# Altium schematic sheet 08_SOM.SchDoc(08_SOM)
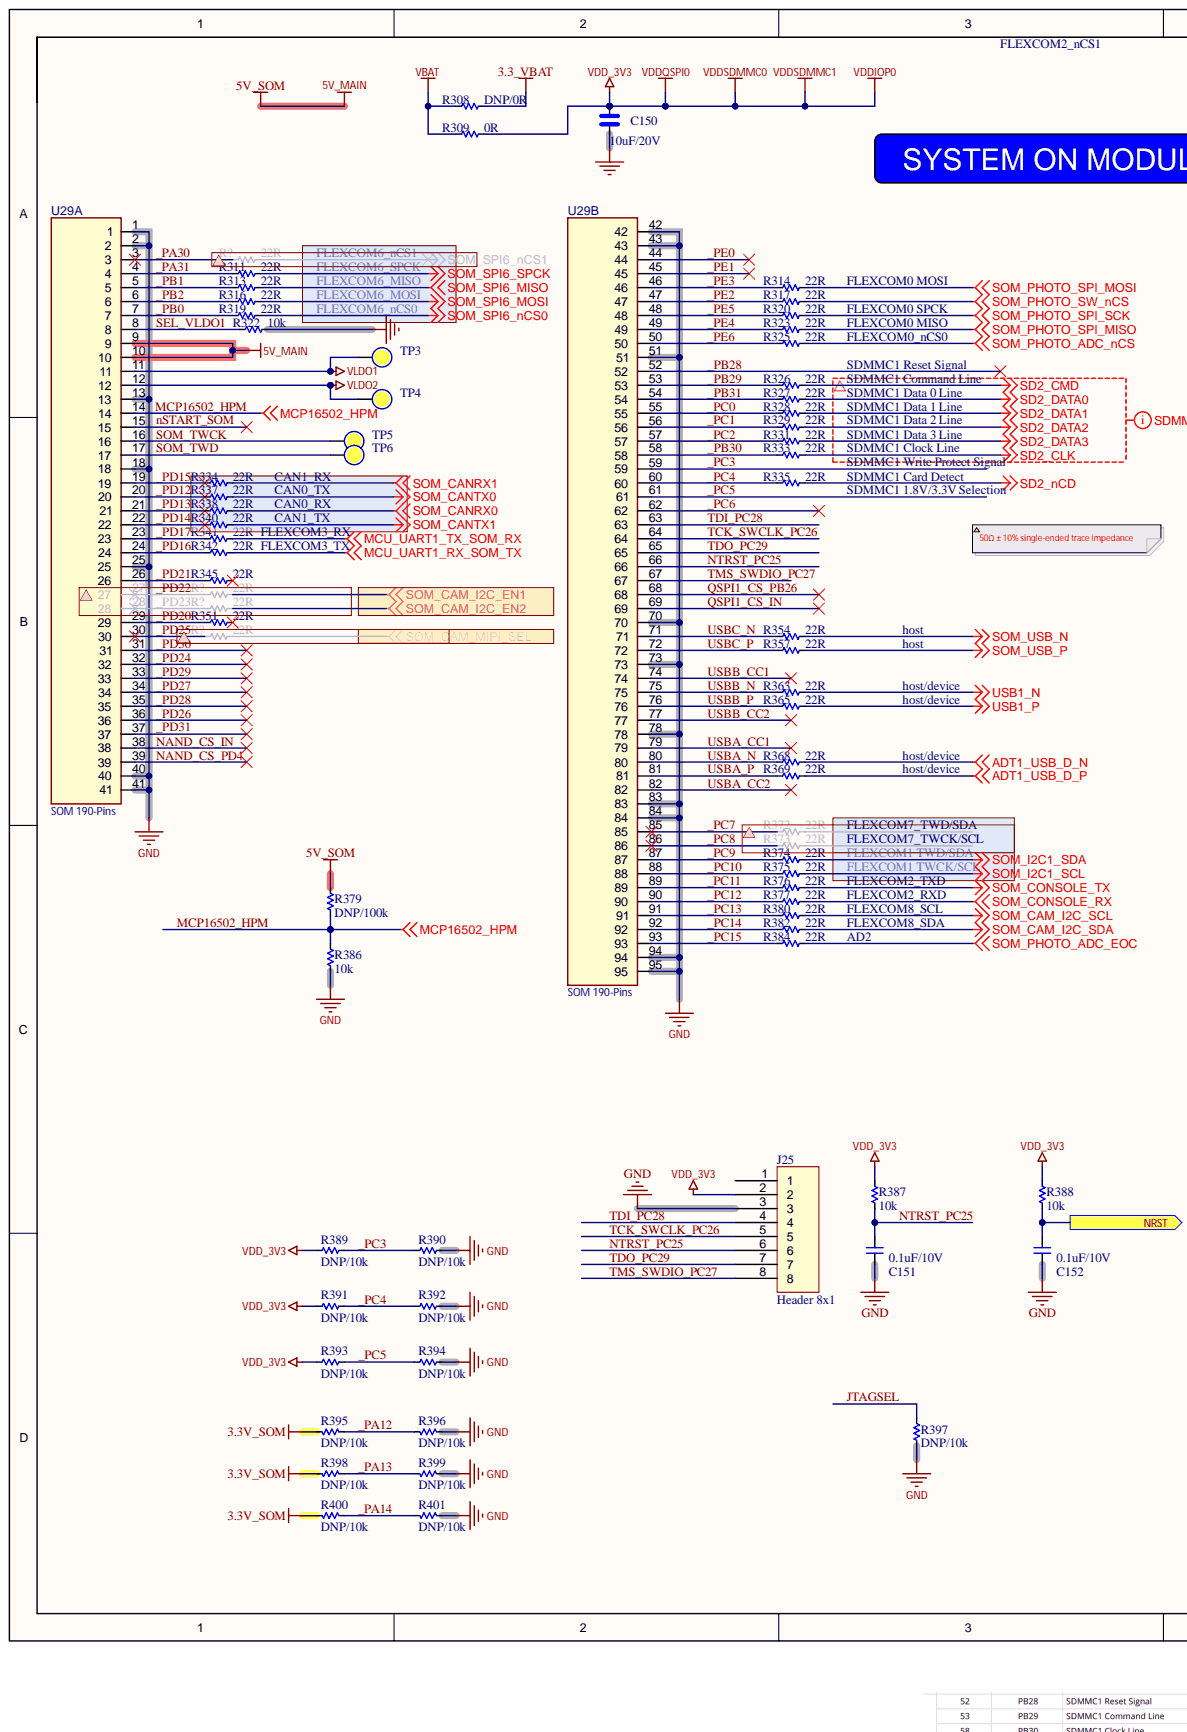
[diagram p0: 08_SOM.SchDoc, left]
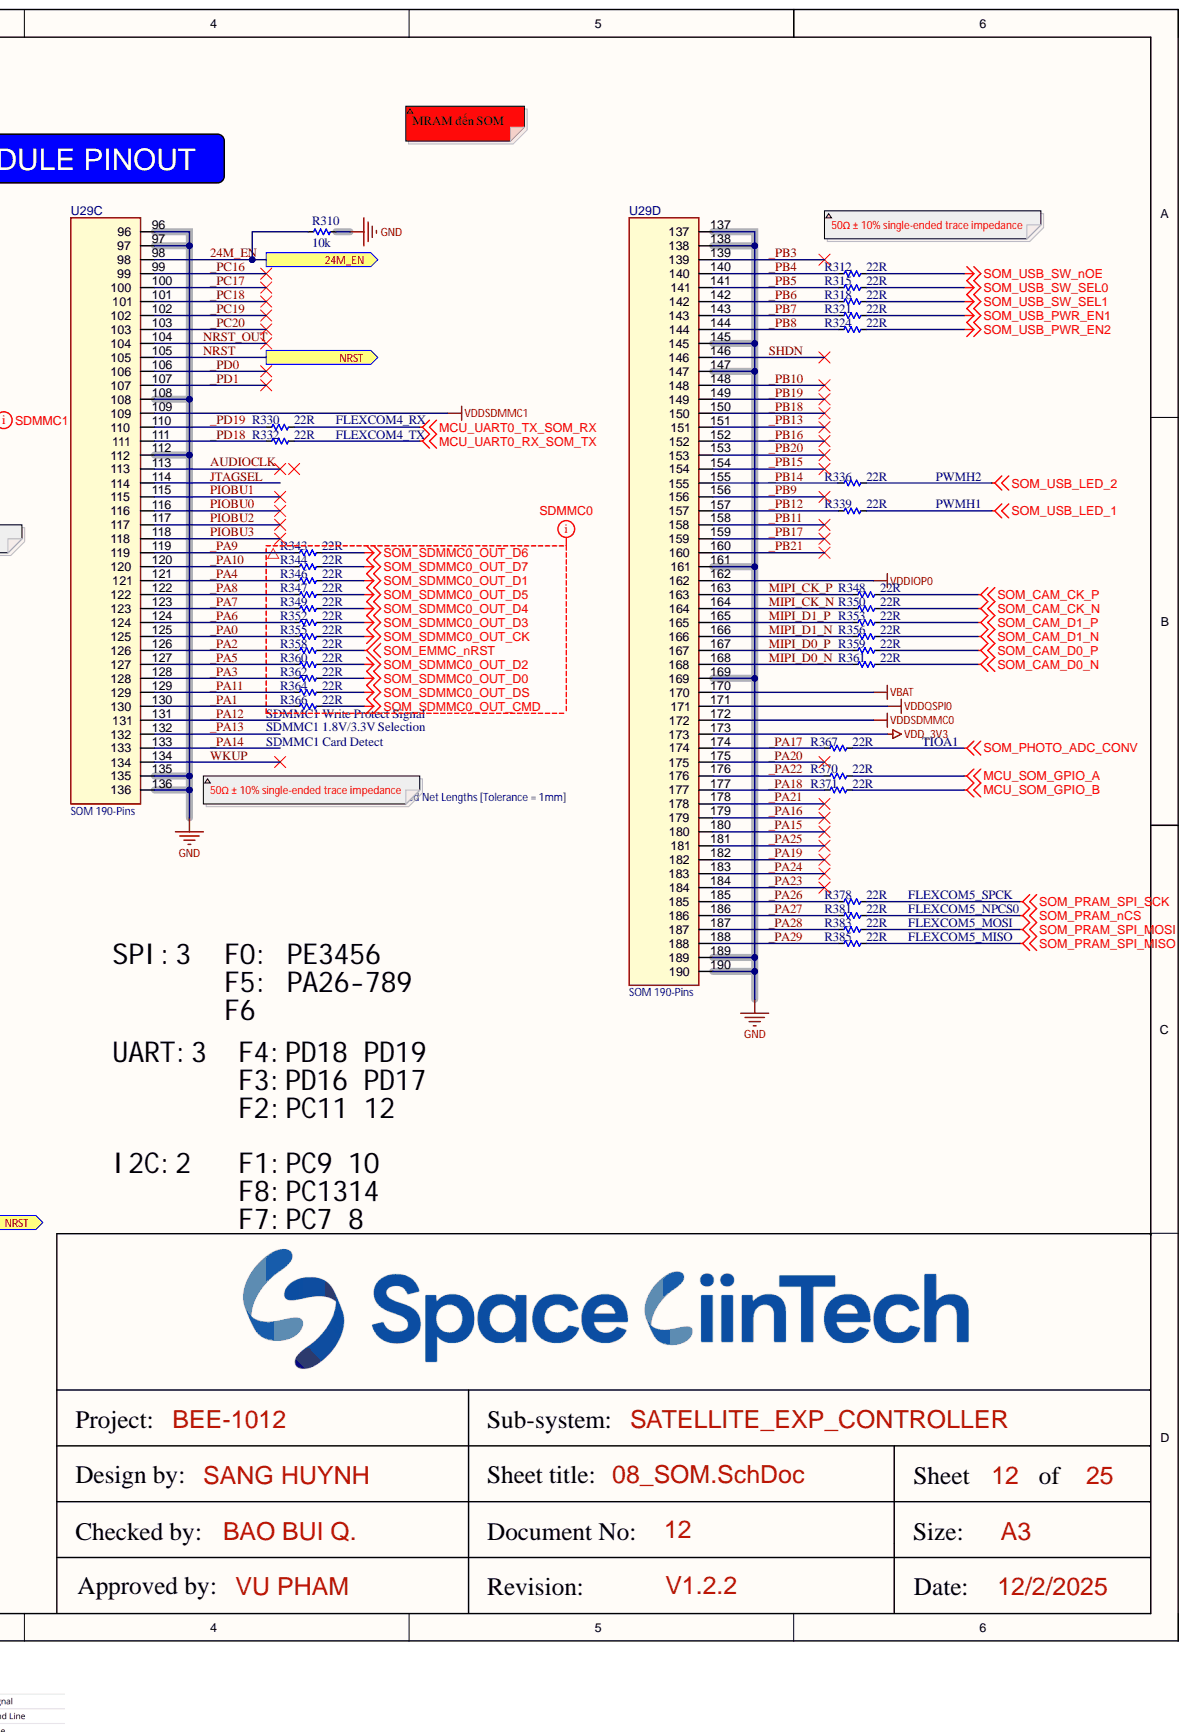
[diagram p1: 08_SOM.SchDoc, right]

## Parts
C150  10uF/20V  [CAP_C_2012X110]
C151  0.1uF/10V  [CAP_C_1005X60]
C152  0.1uF/10V  [CAP_C_1005X60]
J25  Header 8x1  [Header_254P_8x1]
R308  DNP/0R  [RES_C_1005X40]
R309  0R  [RES_C_1005X40]
R310  10k  [RES_C_1005X40]
R311  22R  [RES_C_1005X40]
R312  22R  [RES_C_1005X40]
R313  22R  [RES_C_1005X40]
R314  22R  [RES_C_1005X40]
R315  22R  [RES_C_1005X40]
R316  22R  [RES_C_1005X40]
R317  22R  [RES_C_1005X40]
R318  22R  [RES_C_1005X40]
R319  22R  [RES_C_1005X40]
R320  22R  [RES_C_1005X40]
R321  22R  [RES_C_1005X40]
R322  10k  [RES_C_1005X40]
R323  22R  [RES_C_1005X40]
R324  22R  [RES_C_1005X40]
R325  22R  [RES_C_1005X40]
R326  22R  [RES_C_1005X40]
R327  22R  [RES_C_1005X40]
R328  22R  [RES_C_1005X40]
R329  22R  [RES_C_1005X40]
R330  22R  [RES_C_1005X40]
R331  22R  [RES_C_1005X40]
R332  22R  [RES_C_1005X40]
R333  22R  [RES_C_1005X40]
R334  22R  [RES_C_1005X40]
R335  22R  [RES_C_1005X40]
R336  22R  [RES_C_1005X40]
R337  22R  [RES_C_1005X40]
R338  22R  [RES_C_1005X40]
R339  22R  [RES_C_1005X40]
R340  22R  [RES_C_1005X40]
R341  22R  [RES_C_1005X40]
R342  22R  [RES_C_1005X40]
R343  22R  [RES_C_1005X40]
R344  22R  [RES_C_1005X40]
R345  22R  [RES_C_1005X40]
R346  22R  [RES_C_1005X40]
R347  22R  [RES_C_1005X40]
R348  22R  [RES_C_1005X40]
R349  22R  [RES_C_1005X40]
R350  22R  [RES_C_1005X40]
R351  22R  [RES_C_1005X40]
R352  22R  [RES_C_1005X40]
R353  22R  [RES_C_1005X40]
R354  22R  [RES_C_1005X40]
R355  22R  [RES_C_1005X40]
R356  22R  [RES_C_1005X40]
R357  22R  [RES_C_1005X40]
R358  22R  [RES_C_1005X40]
R359  22R  [RES_C_1005X40]
R360  22R  [RES_C_1005X40]
R361  22R  [RES_C_1005X40]
R362  22R  [RES_C_1005X40]
R363  22R  [RES_C_1005X40]
R364  22R  [RES_C_1005X40]
R365  22R  [RES_C_1005X40]
R366  22R  [RES_C_1005X40]
R367  22R  [RES_C_1005X40]
R368  22R  [RES_C_1005X40]
R369  22R  [RES_C_1005X40]
R370  22R  [RES_C_1005X40]
R371  22R  [RES_C_1005X40]
R374  22R  [RES_C_1005X40]
R375  22R  [RES_C_1005X40]
R376  22R  [RES_C_1005X40]
R377  22R  [RES_C_1005X40]
R378  22R  [RES_C_1005X40]
R379  DNP/100k  [RES_C_1005X40]
R380  22R  [RES_C_1005X40]
R381  22R  [RES_C_1005X40]
R382  22R  [RES_C_1005X40]
R383  22R  [RES_C_1005X40]
R384  22R  [RES_C_1005X40]
R385  22R  [RES_C_1005X40]
R386  10k  [RES_C_1005X40]
R387  10k  [RES_C_1005X40]
R388  10k  [RES_C_1005X40]
R389  DNP/10k  [RES_C_1005X40]
R390  DNP/10k  [RES_C_1005X40]
R391  DNP/10k  [RES_C_1005X40]
R392  DNP/10k  [RES_C_1005X40]
R393  DNP/10k  [RES_C_1005X40]
R394  DNP/10k  [RES_C_1005X40]
R395  DNP/10k  [RES_C_1005X40]
R396  DNP/10k  [RES_C_1005X40]
R397  DNP/10k  [RES_C_1005X40]
R398  DNP/10k  [RES_C_1005X40]
R399  DNP/10k  [RES_C_1005X40]
R400  DNP/10k  [RES_C_1005X40]
R401  DNP/10k  [RES_C_1005X40]
TP3  (no value)  [Testpoint_TH_D130H80]  (no BOM)
TP4  (no value)  [Testpoint_TH_D130H80]  (no BOM)
TP5  (no value)  [Testpoint_TH_D130H80]  (no BOM)
TP6  (no value)  [Testpoint_TH_D130H80]  (no BOM)
U29  SOM 190-Pins  [SOM-38MMX30MM]  (no BOM)  (parts A,B,C,D)

## Ports
24M_EN, NRST

## Nets
3.3_VBAT: R308.1
3.3V_SOM: R395.2 R398.2 R400.2
5V_MAIN: R379.1 U29.9 U29.10
ADT1_USB_D_N: R368.1
ADT1_USB_D_P: R369.1
GND: C150.2 C151.1 C152.1 J25.3 R310.1 R322.1 R386.2 R390.1 R392.1 R394.1 R396.1 R397.1 R399.1 R401.1 U29.1 U29.2 U29.13 U29.18 U29.25 U29.40 U29.41 U29.42 U29.43 U29.51 U29.70 U29.73 U29.78 U29.83 U29.84 U29.94 U29.95 U29.96 U29.97 U29.108 U29.112 U29.135 U29.136 U29.137 U29.138 U29.145 U29.147 U29.161 U29.169 U29.189 U29.190
MCU_SOM_GPIO_A: R370.1
MCU_SOM_GPIO_B: R371.1
MCU_UART0_RX_SOM_TX: R332.1
MCU_UART0_TX_SOM_RX: R330.1
MCU_UART1_RX_SOM_TX: R342.1
MCU_UART1_TX_SOM_RX: R341.1
SD2_CLK: R333.1
SD2_CMD: R326.1
SD2_DATA0: R327.1
SD2_DATA1: R328.1
SD2_DATA2: R329.1
SD2_DATA3: R331.1
SD2_nCD: R335.1
SOM_CAM_CK_N: R350.1
SOM_CAM_CK_P: R348.1
SOM_CAM_D0_N: R361.1
SOM_CAM_D0_P: R359.1
SOM_CAM_D1_N: R356.1
SOM_CAM_D1_P: R353.1
SOM_CAM_I2C_SCL: R380.1
SOM_CAM_I2C_SDA: R382.1
SOM_CANRX0: R338.1
SOM_CANRX1: R334.1
SOM_CANTX0: R337.1
SOM_CANTX1: R340.1
SOM_CONSOLE_RX: R377.1
SOM_CONSOLE_TX: R376.1
SOM_EMMC_nRST: R358.1
SOM_I2C1_SCL: R375.1
SOM_I2C1_SDA: R374.1
SOM_PHOTO_ADC_CONV: R367.1
SOM_PHOTO_ADC_EOC: R384.1
SOM_PHOTO_ADC_nCS: R325.1
SOM_PHOTO_SPI_MISO: R323.1
SOM_PHOTO_SPI_MOSI: R314.1
SOM_PHOTO_SPI_SCK: R320.1
SOM_PHOTO_SW_nCS: R317.1
SOM_PRAM_nCS: R381.1
SOM_PRAM_SPI_MISO: R385.1
SOM_PRAM_SPI_MOSI: R383.1
SOM_PRAM_SPI_SCK: R378.1
SOM_SDMMC0_OUT_CK: R355.1
SOM_SDMMC0_OUT_CMD: R366.1
SOM_SDMMC0_OUT_D0: R362.1
SOM_SDMMC0_OUT_D1: R346.1
SOM_SDMMC0_OUT_D2: R360.1
SOM_SDMMC0_OUT_D3: R352.1
SOM_SDMMC0_OUT_D4: R349.1
SOM_SDMMC0_OUT_D5: R347.1
SOM_SDMMC0_OUT_D6: R343.1
SOM_SDMMC0_OUT_D7: R344.1
SOM_SDMMC0_OUT_DS: R364.1
SOM_SPI6_MISO: R313.1
SOM_SPI6_MOSI: R316.1
SOM_SPI6_nCS0: R319.1
SOM_SPI6_SPCK: R311.1
SOM_USB_LED_1: R339.1
SOM_USB_LED_2: R336.1
SOM_USB_N: R354.1
SOM_USB_P: R357.1
SOM_USB_PWR_EN1: R321.1
SOM_USB_PWR_EN2: R324.1
SOM_USB_SW_nOE: R312.1
SOM_USB_SW_SEL0: R315.1
SOM_USB_SW_SEL1: R318.1
USB1_N: R363.1
USB1_P: R365.1
VBAT: R308.2 R309.2 U29.170
VDD_3V3: C150.1 J25.2 R309.1 R387.2 R388.2 R389.2 R391.2 R393.2 U29.109 U29.162 U29.171 U29.172 U29.173
VLDO1: TP3.0 U29.11
VLDO2: TP4.0 U29.12
24M_EN: R310.2 U29.98 ; port 24M_EN
_PA0: R355.2 U29.125
_PA1: R366.2 U29.130
_PA2: R358.2 U29.126
_PA3: R362.2 U29.128
_PA4: R346.2 U29.121
_PA5: R360.2 U29.127
_PA6: R352.2 U29.124
_PA7: R349.2 U29.123
_PA8: R347.2 U29.122
_PA9: R343.2 U29.119
_PA10: R344.2 U29.120
_PA11: R364.2 U29.129
_PA12: R395.1 R396.2 U29.131
_PA13: R398.1 R399.2 U29.132
_PA14: R400.1 R401.2 U29.133
_PA15: U29.180
_PA16: U29.179
_PA17: R367.2 U29.174
_PA18: R371.2 U29.177
_PA19: U29.182
_PA20: U29.175
_PA21: U29.178
_PA22: R370.2 U29.176
_PA23: U29.184
_PA24: U29.183
_PA25: U29.181
_PA26: R378.2 U29.185
_PA27: R381.2 U29.186
_PA28: R383.2 U29.187
_PA29: R385.2 U29.188
_PA30: U29.3
_PA31: R311.2 U29.4
_PB0: R319.2 U29.7
_PB1: R313.2 U29.5
_PB2: R316.2 U29.6
_PB3: U29.139
_PB4: R312.2 U29.140
_PB5: R315.2 U29.141
_PB6: R318.2 U29.142
_PB7: R321.2 U29.143
_PB8: R324.2 U29.144
_PB9: U29.156
_PB10: U29.148
_PB11: U29.158
_PB12: R339.2 U29.157
_PB13: U29.151
_PB14: R336.2 U29.155
_PB15: U29.154
_PB16: U29.152
_PB17: U29.159
_PB18: U29.150
_PB19: U29.149
_PB20: U29.153
_PB21: U29.160
_PB28: U29.52
_PB29: R326.2 U29.53
_PB30: R333.2 U29.58
_PB31: R327.2 U29.54
_PC0: R328.2 U29.55
_PC1: R329.2 U29.56
_PC2: R331.2 U29.57
_PC3: R389.1 R390.2 U29.59
_PC4: R335.2 R391.1 R392.2 U29.60
_PC5: R393.1 R394.2 U29.61
_PC6: U29.62
_PC7: U29.85
_PC8: U29.86
_PC9: R374.2 U29.87
_PC10: R375.2 U29.88
_PC11: R376.2 U29.89
_PC12: R377.2 U29.90
_PC13: R380.2 U29.91
_PC14: R382.2 U29.92
_PC15: R384.2 U29.93
_PC16: U29.99
_PC17: U29.100
_PC18: U29.101
_PC19: U29.102
_PC20: U29.103
_PD0: U29.106
_PD1: U29.107
_PD12: R337.2 U29.20
_PD13: R338.2 U29.21
_PD14: R340.2 U29.22
_PD15: R334.2 U29.19
_PD16: R342.2 U29.24
_PD17: R341.2 U29.23
_PD18: R332.2 U29.111
_PD19: R330.2 U29.110
_PD20: R351.2 U29.29
_PD21: R345.2 U29.26
_PD24: U29.32
_PD25: U29.30
_PD26: U29.36
_PD27: U29.34
_PD28: U29.35
_PD29: U29.33
_PD30: U29.31
_PD31: U29.37
_PE0: U29.44
_PE1: U29.45
_PE2: R317.2 U29.47
_PE3: R314.2 U29.46
_PE4: R323.2 U29.49
_PE5: R320.2 U29.48
_PE6: R325.2 U29.50
AUDIOCLK: U29.113
JTAGSEL: R397.2 U29.114
MCP16502_HPM: R379.2 R386.1 U29.14
MIPI_CK_N: R350.2 U29.164
MIPI_CK_P: R348.2 U29.163
MIPI_D0_N: R361.2 U29.168
MIPI_D0_P: R359.2 U29.167
MIPI_D1_N: R356.2 U29.166
MIPI_D1_P: R353.2 U29.165
NAND_CS_IN: U29.38
NAND_CS_PD4: U29.39
NRST: C152.2 R388.1 U29.105 ; port NRST
NRST_OUT: U29.104
nSTART_SOM: U29.15
NTRST_PC25: C151.2 J25.6 R387.1 U29.66
PIOBU0: U29.116
PIOBU1: U29.115
PIOBU2: U29.117
PIOBU3: U29.118
QSPI1_CS_IN: U29.69
QSPI1_CS_PB26: U29.68
SEL_VLDO1: R322.2 U29.8
SHDN: U29.146
SOM_TWCK: TP5.0 U29.16
SOM_TWD: TP6.0 U29.17
TCK_SWCLK_PC26: J25.5 U29.64
TDI_PC28: J25.4 U29.63
TDO_PC29: J25.7 U29.65
TMS_SWDIO_PC27: J25.8 U29.67
USBA_CC1: U29.79
USBA_CC2: U29.82
USBA_N: R368.2 U29.80
USBA_P: R369.2 U29.81
USBB_CC1: U29.74
USBB_CC2: U29.77
USBB_N: R363.2 U29.75
USBB_P: R365.2 U29.76
USBC_N: R354.2 U29.71
USBC_P: R357.2 U29.72
WKUP: U29.134
SOM_CAM_I2C_EN1:
SOM_CAM_I2C_EN2:

Not connected: J25 1; R345 1; R351 1

## Library data of the parts
C150 = _CAP_C_High_2: CAP CHIP High Value; Component Kind: Standard; Library Name: LABLIB.SchLib
C151 C152 = _CAP_C_2: CAP CHIP; Component Kind: Standard; Library Name: LABLIB.SchLib
R308-R371 R374-R401 = _RES_B_2: RES; Component Kind: Standard; Library Name: LABLIB.SchLib
TP3-TP6 = Test Point: Solder: NF; Description: Test Point; Library Name: LABLIB.SchLib
U29 = SOM-190: 190-Castellated thru-holes, 38mm x 30mm package; Library Name: SOM-190.SchLib
J25 = Header 8x1: Component Kind: Standard; Library Name: LABLIB.SchLib
